# Altium schematic sheet 扩展接口.SchDoc(扩展接口)
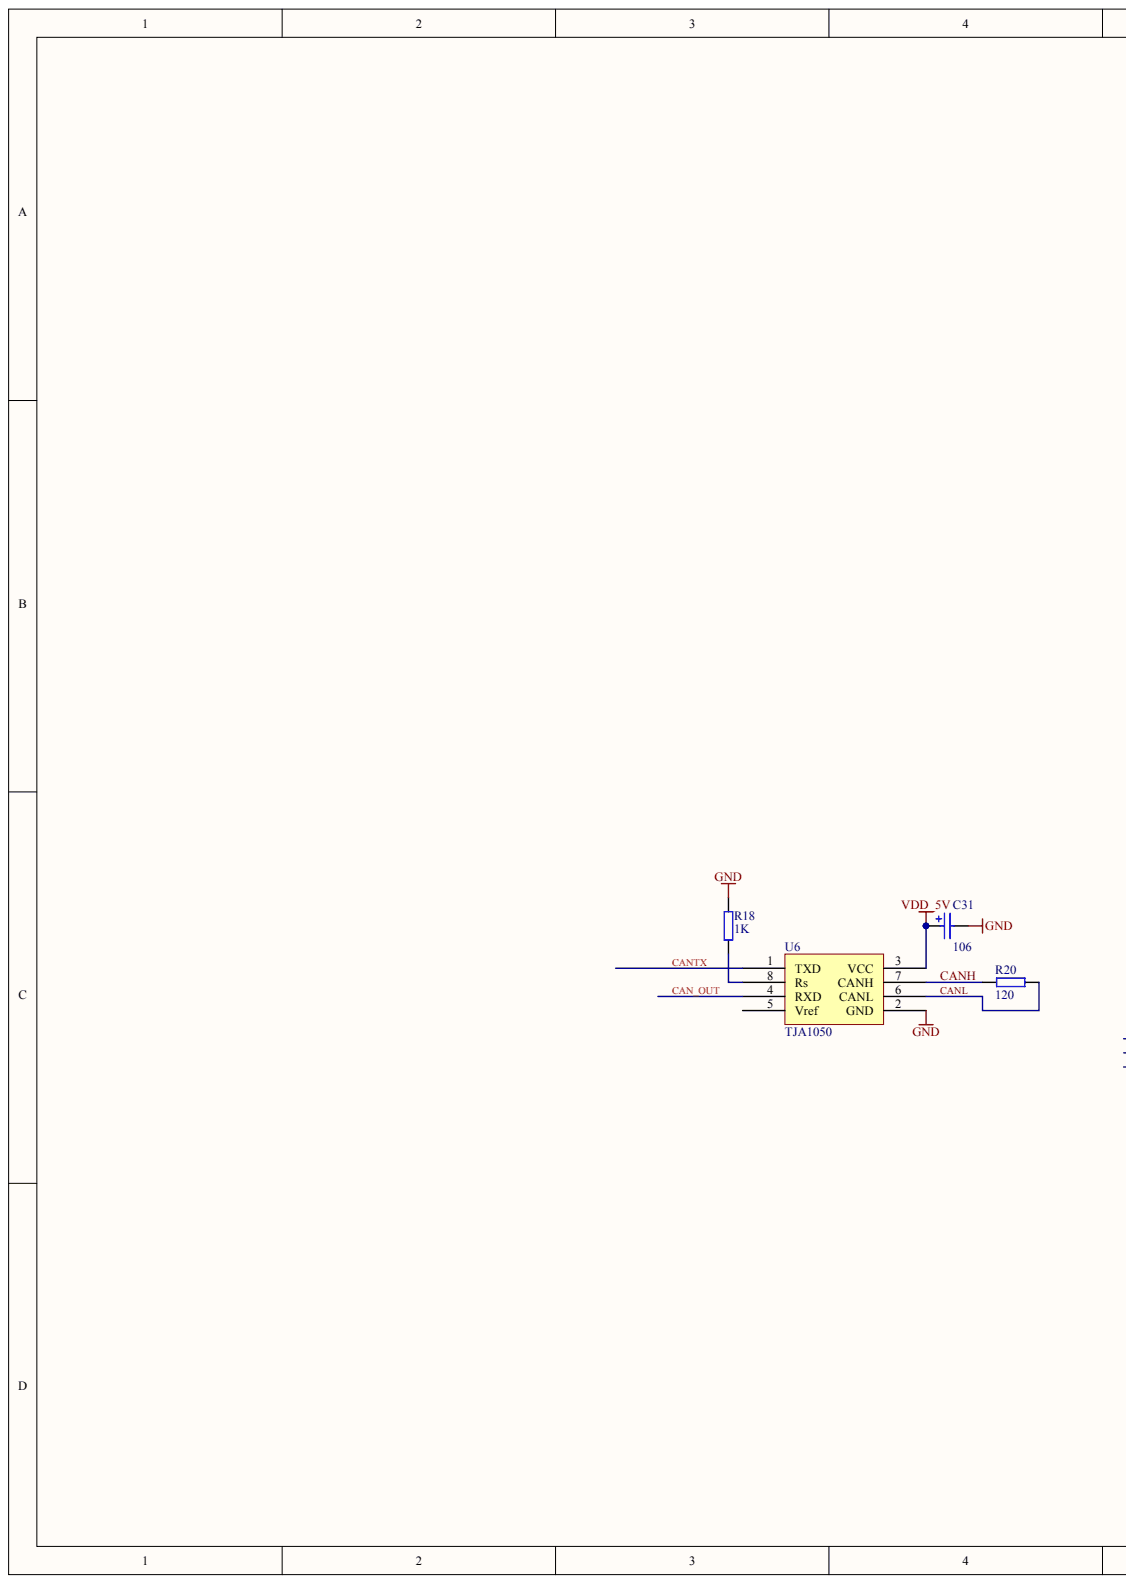
[diagram p0: 扩展接口.SchDoc, left]
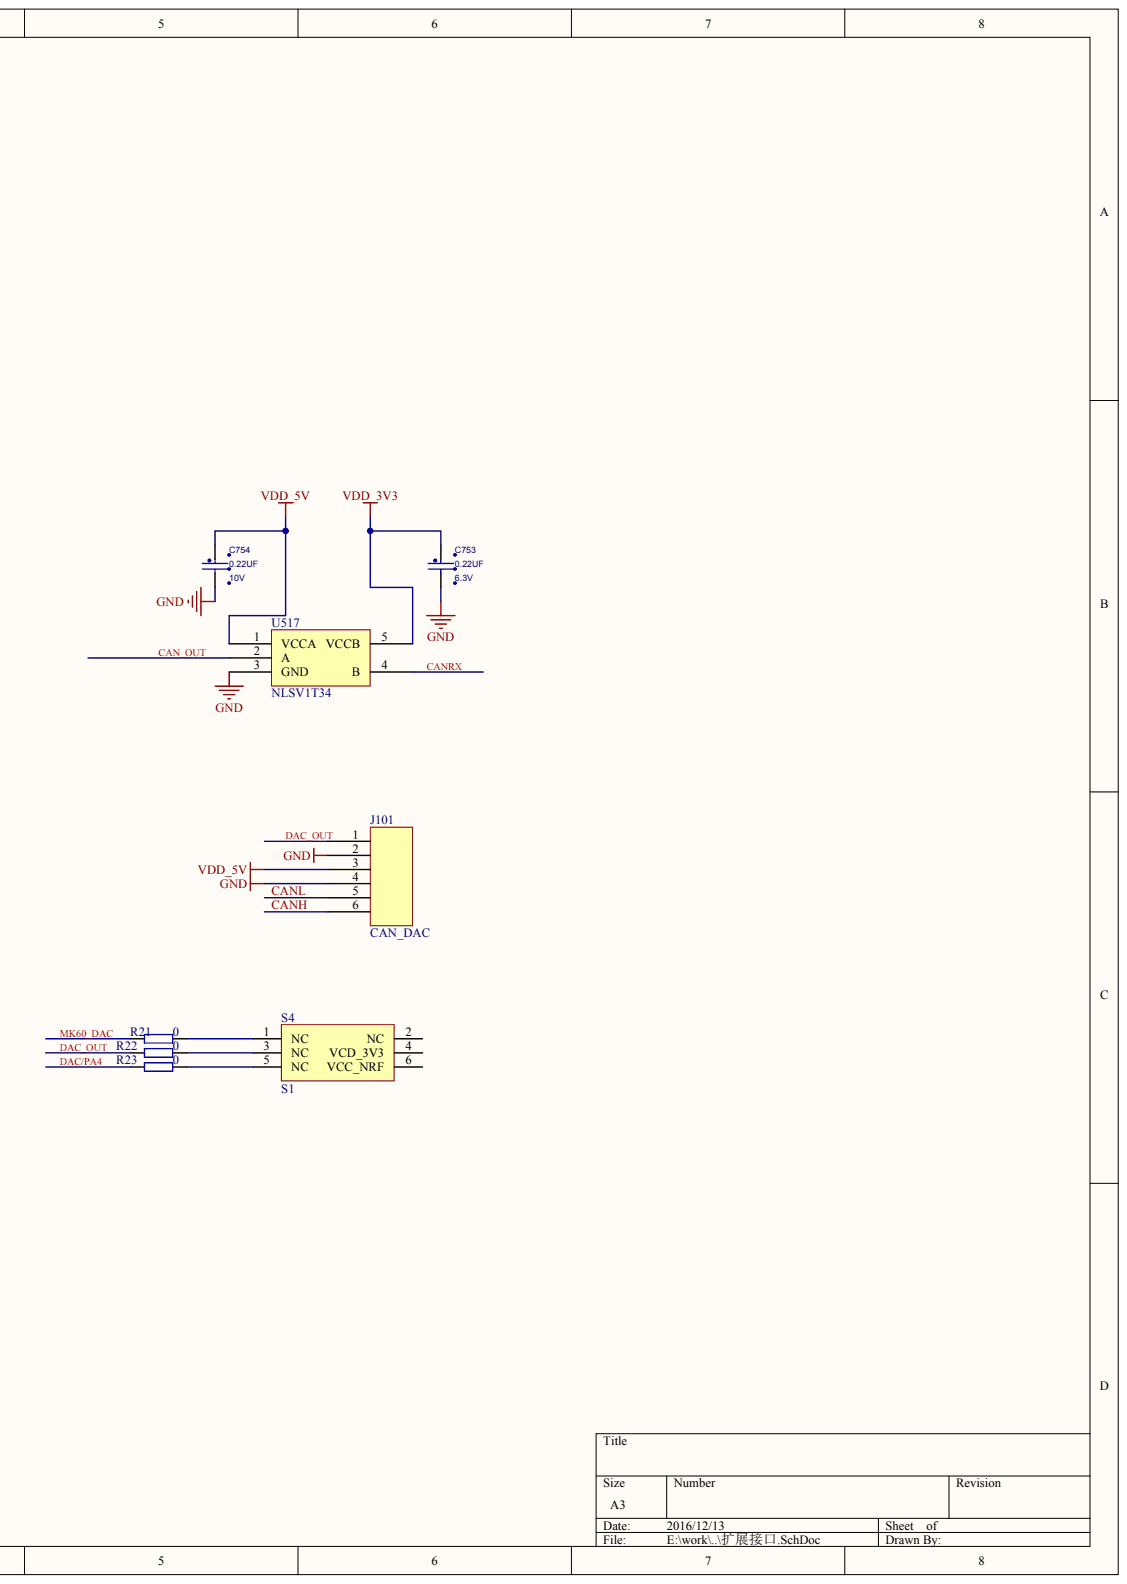
[diagram p1: 扩展接口.SchDoc, right]

## Parts
C31  106
C753  0.22UF  VOLTAGE: 6.3V
C754  0.22UF  VOLTAGE: 10V
J101  CAN_DAC
R18  1K
R20  120
R21  0
R22  0
R23  0
S4  S1
U6  TJA1050
U517  NLSV1T34

## Nets
GND: C31.2 C753.2 C754.2 J101.2 J101.4 R18.2 U6.2(GND) U517.3(GND)
VDD_3V3: C753.1 U517.5(VCCB)
VDD_5V: C31.1 C754.1 J101.3 U6.3(VCC) U517.1(VCCA)
CAN_OUT: U6.4(RXD) U517.2(A)
CANH: J101.6 R20.1 U6.7(CANH)
CANL: J101.5 R20.2 U6.6(CANL)
CANRX: U517.4(B)
CANTX: U6.1(TXD)
DAC/PA4: R23.1
DAC_OUT: J101.1 R22.1
MK60_DAC: R21.1
NetR18_1: R18.1 U6.8(Rs)
NetR21_2: R21.2 S4.1(NC)
NetR22_2: R22.2 S4.3(NC)
NetR23_2: R23.2 S4.5(NC)

Not connected: S4 2(NC) 4(VCD_3V3) 6(VCC_NRF); U6 5(Vref)

## Library data of the parts
C753 = (no symbol name): CLASS: DISCRETE; PRIORITY_PN: 021-00278; FPLIST: CC0201,CC0201_HD,0201_CC,cc_0201_cir; CAD_APPROVED: Yes; MFG_PN01: JMK063BJ224MP-F; MFG_NAME01: TAIYO YUDEN; Tolerance: 20%; AGILE_PN: 150-77852; ROOM: CPU; SIGNAL_MODEL: DEFAULT_CAPACITOR_220000pF_2_1; WVDC: 6.3V; HEIGHT: 0.33 MM; ROHS: Yes
C754 = (no symbol name): CLASS: DISCRETE; ROOM: CPU; AGILE_PN: 150-78815; Tolerance: 20%; MFG_NAME01: Taiyo Yuden; MFG_PN01: LMK063BJ224MP-F; CAD_APPROVED: Yes; FPLIST: CC0201,CC0201_HD,0201_CC,CC_0201_CIR,0201_CC_012SM; PRIORITY_PN: 021-00278; SIGNAL_MODEL: DEFAULT_CAPACITOR_220000pF_2_1; ROHS: Yes; HEIGHT: 0.33MM; WVDC: 10V
